# Altium schematic sheet ALARM SENSOR and CT SENSOR.SchDoc("ALARM SENSOR and CT SENSOR")
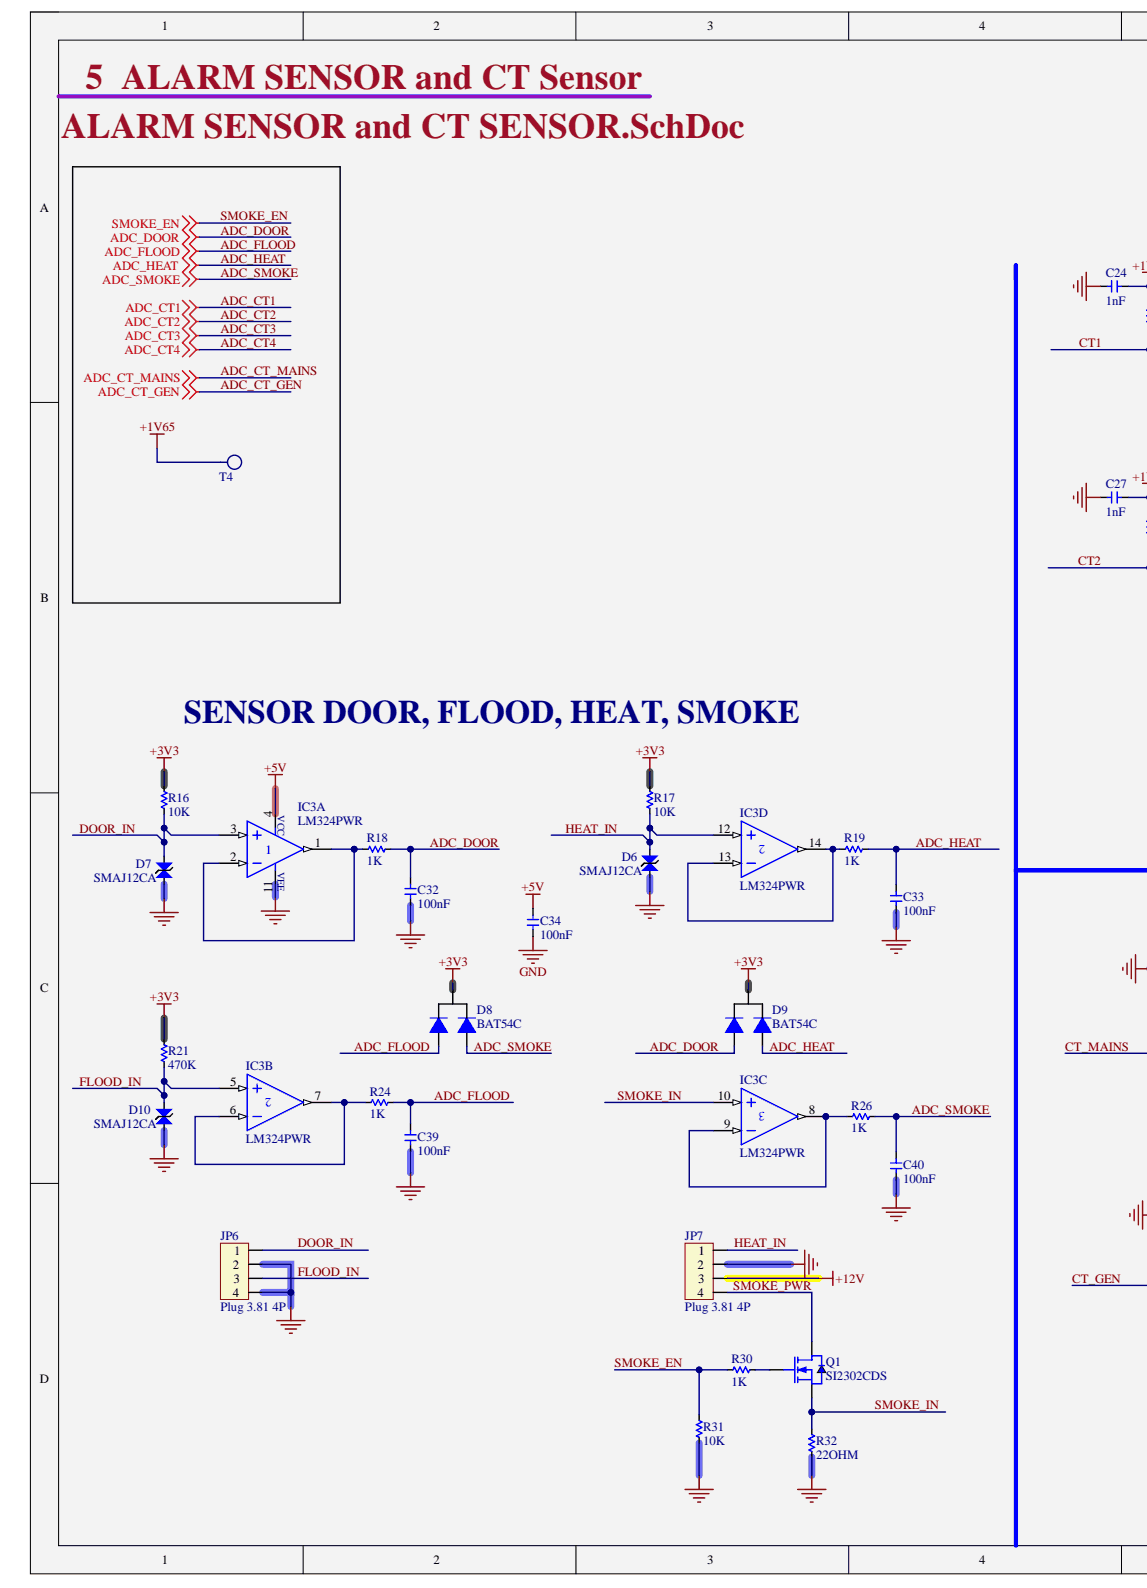
[diagram p0: ALARM SENSOR and CT SENSOR.SchDoc, left]
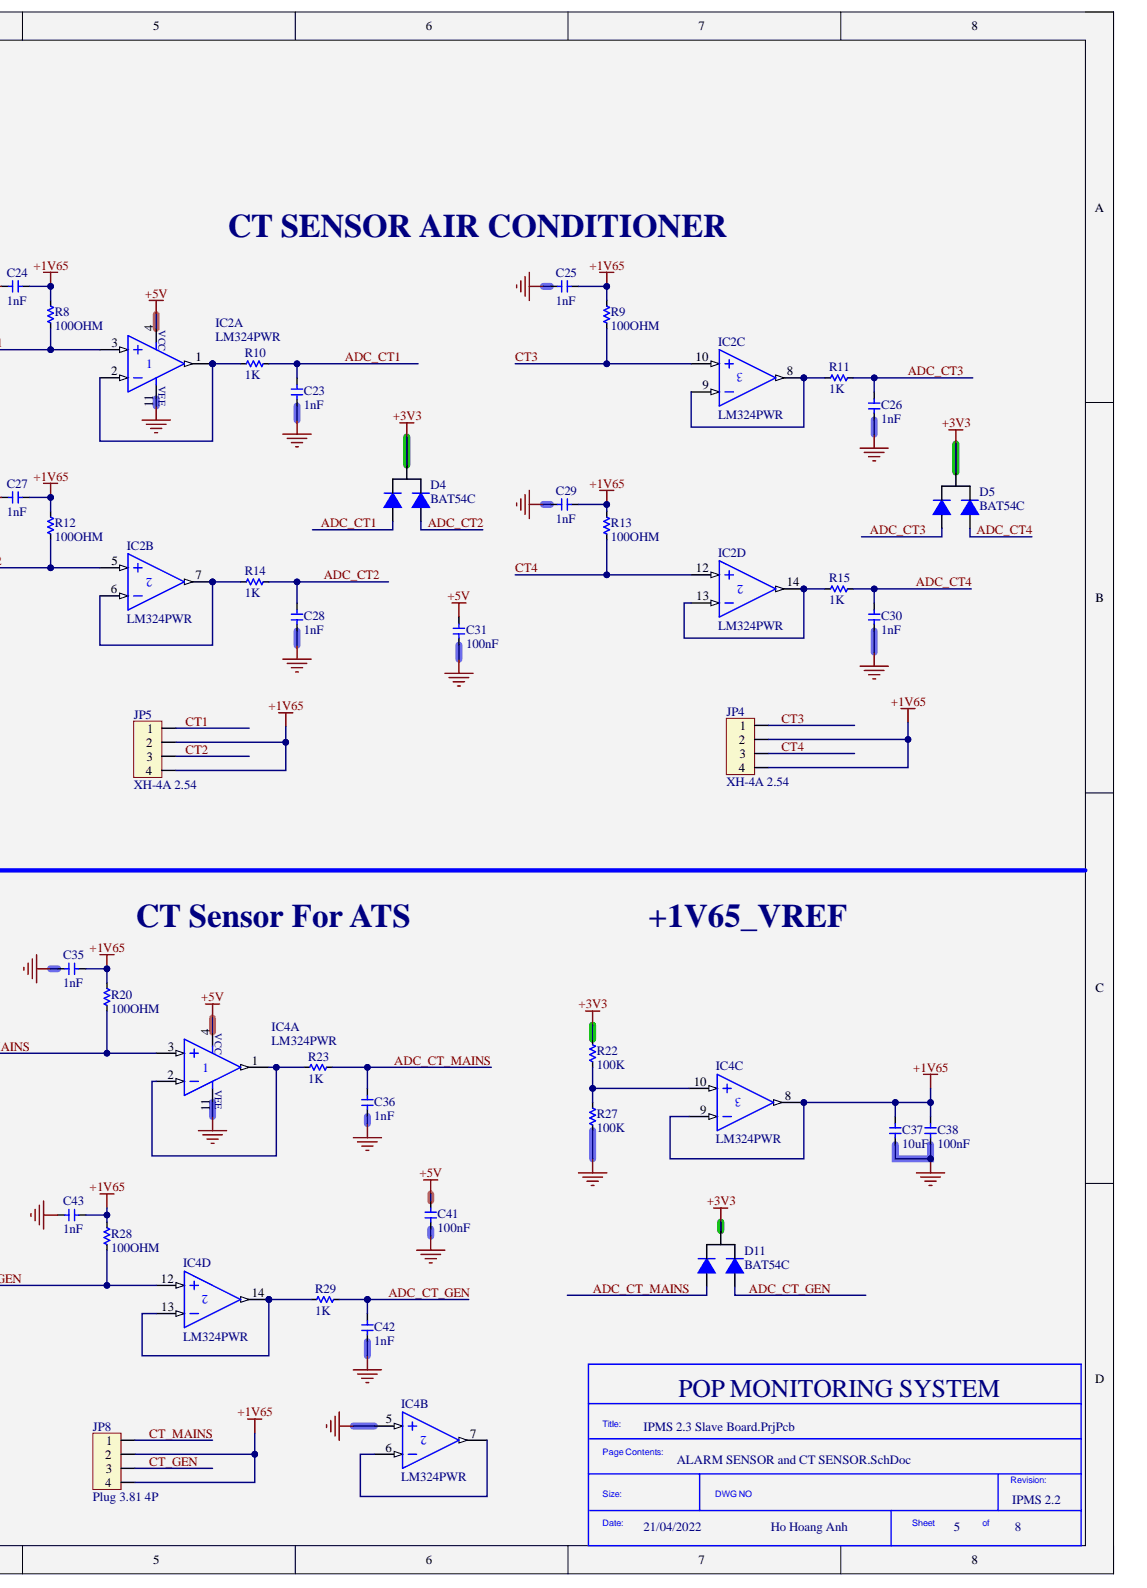
[diagram p1: ALARM SENSOR and CT SENSOR.SchDoc, right]

## Parts
C23  1nF  [C_0603]
C24  1nF  [C_0603]
C25  1nF  [C_0603]
C26  1nF  [C_0603]
C27  1nF  [C_0603]
C28  1nF  [C_0603]
C29  1nF  [C_0603]
C30  1nF  [C_0603]
C31  100nF  [C_0603]
C32  100nF  [C_0603]
C33  100nF  [C_0603]
C34  100nF  [C_0603]
C35  1nF  [C_0603]
C36  1nF  [C_0603]
C37  10uF  [C_0805]
C38  100nF  [C_0603]
C39  100nF  [C_0603]
C40  100nF  [C_0603]
C41  100nF  [C_0603]
C42  1nF  [C_0603]
C43  1nF  [C_0603]
D4  BAT54C  [SOT-23-3]
D5  BAT54C  [SOT-23-3]
D6  SMAJ12CA  [SMA_L4.4-W2.6-LS5.0-BI]
D7  SMAJ12CA  [SMA_L4.4-W2.6-LS5.0-BI]
D8  BAT54C  [SOT-23-3]
D9  BAT54C  [SOT-23-3]
D10  SMAJ12CA  [SMA_L4.4-W2.6-LS5.0-BI]
D11  BAT54C  [SOT-23-3]
IC2  LM324PWR  [TSSOP-14_L5.0-W4.4-P0.65-LS6.4-BL]  (parts A,B,C,D)
IC3  LM324PWR  [TSSOP-14_L5.0-W4.4-P0.65-LS6.4-BL]  (parts A,B,C,D)
IC4  LM324PWR  [TSSOP-14_L5.0-W4.4-P0.65-LS6.4-BL]  (parts A,B,C,D)
JP4  XH-4A 2.54  [XH-4A-2.54]
JP5  XH-4A 2.54  [XH-4A-2.54]
JP6  Plug 3.81 4P  [CONN-TH_1803442]
JP7  Plug 3.81 4P  [CONN-TH_1803442]
JP8  Plug 3.81 4P  [CONN-TH_1803442]
Q1  SI2302CDS  [SOT-23-3]
R8  100OHM  [R_0805]
R9  100OHM  [R_0805]
R10  1K  [R_0603]
R11  1K  [R_0603]
R12  100OHM  [R_0805]
R13  100OHM  [R_0805]
R14  1K  [R_0603]
R15  1K  [R_0603]
R16  10K  [R_0805]
R17  10K  [R_0805]
R18  1K  [R_0603]
R19  1K  [R_0603]
R20  100OHM  [R_0805]
R21  470K  [R_0805]
R22  100K  [R_0603]
R23  1K  [R_0603]
R24  1K  [R_0603]
R26  1K  [R_0603]
R27  100K  [R_0603]
R28  100OHM  [R_0805]
R29  1K  [R_0603]
R30  1K  [R_0603]
R31  10K  [R_0603]
R32  22OHM  [R_1206]
T4  TEST-POINT'4545'  [TP-D45MIL]  (value not drawn)  (no BOM)

## Nets
+1V65: C24.2 C25.2 C27.2 C29.1 C35.1 C37.2 C38.2 C43.2 IC4.8 IC4.9 JP4.2 JP4.4 JP5.2 JP5.4 JP8.2 JP8.4 R8.1 R9.1 R12.1 R13.1 R20.1 R28.1 T4.T
+3V3: D4.3 D5.3 D8.3 D9.3 D11.3 R16.1 R17.1 R21.1 R22.1
+5V: C31.2 C34.2 C41.2 IC2.4 IC3.4 IC4.4
+12V: JP7.3
GND: C23.1 C24.1 C25.1 C26.1 C27.1 C28.1 C29.2 C30.1 C31.1 C32.1 C33.1 C34.1 C35.2 C36.1 C37.1 C38.1 C39.1 C40.1 C41.1 C42.1 C43.1 D6.1 D7.1 D10.1 IC2.11 IC3.11 IC4.5 IC4.11 JP6.2 JP6.4 JP7.2 R27.2 R31.2 R32.2
ADC_CT1: C23.2 D4.2 R10.1
ADC_CT2: C28.2 D4.1 R14.1
ADC_CT3: C26.2 D5.2 R11.1
ADC_CT4: C30.2 D5.1 R15.1
ADC_CT_GEN: C42.2 D11.1 R29.1
ADC_CT_MAINS: C36.2 D11.2 R23.1
ADC_DOOR: C32.2 D9.2 R18.1
ADC_FLOOD: C39.2 D8.2 R24.1
ADC_HEAT: C33.2 D9.1 R19.1
ADC_SMOKE: C40.2 D8.1 R26.1
CT1: IC2.3 JP5.1 R8.2
CT2: IC2.5 JP5.3 R12.2
CT3: IC2.10 JP4.1 R9.2
CT4: IC2.12 JP4.3 R13.2
CT_GEN: IC4.12 JP8.3 R28.2
CT_MAINS: IC4.3 JP8.1 R20.2
DOOR_IN: D7.2 IC3.3 JP6.1 R16.2
FLOOD_IN: D10.2 IC3.5 JP6.3 R21.2
HEAT_IN: D6.2 IC3.12 JP7.1 R17.2
SMOKE_EN: R30.2 R31.1
SMOKE_IN: IC3.10 Q1.2 R32.1
SMOKE_PWR: JP7.4 Q1.3
NetIC2_1: IC2.1 IC2.2 R10.2
NetIC2_6: IC2.6 IC2.7 R14.2
NetIC2_8: IC2.8 IC2.9 R11.2
NetIC2_13: IC2.13 IC2.14 R15.2
NetIC3_1: IC3.1 IC3.2 R18.2
NetIC3_6: IC3.6 IC3.7 R24.2
NetIC3_8: IC3.8 IC3.9 R26.2
NetIC3_13: IC3.13 IC3.14 R19.2
NetIC4_1: IC4.1 IC4.2 R23.2
NetIC4_6: IC4.6 IC4.7
NetIC4_10: IC4.10 R22.2 R27.1
NetIC4_13: IC4.13 IC4.14 R29.2
NetQ1_1: Q1.1 R30.1

## Library data of the parts
C23-C30 C35 C36 C42 C43 = CL10B102KB8NNNC: 1NF 50V 0603 ROHS; Manufacturer: Samsung Electro-Mechanics; Assembly Type: SMT Assembly; Component Kind: Standard; LCSC Part Number: C1588; Manufacturer Part Number: CL10B102KB8NNNC; Package: 0603; Library Name: IPMS 2.3 Slave Board.SCHLIB
C31-C34 C38-C41 = CC0603KRX7R9BB104: 100nF 50V X7R ±10% 0603 Multilayer Ceramic Capacitors MLCC - SMD/SMT ROHS; Manufacturer: YAGEO; Assembly Type: SMT Assembly; Component Kind: Standard; LCSC Part Number: C14663; Manufacturer Part Number: CC0603KRX7R9BB104; Package: 0603; Library Name: IPMS 2.3 Slave Board.SCHLIB
C37 = CL21A106KAYNNNE: 10UF 25V 0805 ROHS; Manufacturer: Samsung Electro-Mechanics; Assembly Type: SMT Assembly; Component Kind: Standard; LCSC Part Number: C15850; Manufacturer Part Number: CL21A106KAYNNNE; Package: 0805; Library Name: IPMS 2.3 Slave Board.SCHLIB
D4 D5 D8 D9 D11 = BAT54C-7-F: DIODE ARRAY SCHOTTKY 30V SOT23-3; Manufacturer: Diodes; Assembly Type: SMT Assembly; Component Kind: Standard; LCSC Part Number: C134405; Manufacturer Part Number: BAT54C-7-F; Package: SOT-23-3; Library Name: IPMS 2.3 Slave Board.SCHLIB
D6 D7 D10 = SMAJ12CA: TVS DIODE 12V 19.9V SMA; Manufacturer: MDD（Microdiode Electronics）; Assembly Type: SMT Assembly; Component Kind: Standard; LCSC Part Number: C110042; Manufacturer Part Number: SMAJ12CA; Package: SMA,DO-214AC; Library Name: IPMS 2.3 Slave Board.SCHLIB
IC2-IC4 = LM324PWR: LM324AM/NOPB GENERAL PURPOSE AMPLIFIERS SOIC-14 ROHS; Manufacturer: Texas Instruments; Assembly Type: SMT Assembly; Component Kind: Standard; LCSC Part Number: C7942; Manufacturer Part Number: LM324PWR; Package: TSSOP-14_4.4x5.0x0.65P; Library Name: IPMS 2.3 Slave Board.SCHLIB
JP4 JP5 = XH-4A 2.54: 1x4P, Brass Plugin,P=2.54mm Wire To Board / Wire To Wire Connector ROHS; Manufacturer: China; Assembly Type: Hand Soldering; Component Kind: Standard; LCSC Part Number: N/A; Manufacturer Part Number: XH-4A 2.54; Package: CONN-TH_4P_XH Pitch=2.54; Library Name: IPMS 2.3 Slave Board.SCHLIB
JP6-JP8 = 1803442: 160V 8A 4 Straight pin 1 3.81mm Green Board Edge/Receptacle P=3.81mm Pluggable System Terminal Block ROHS; Manufacturer: Phoenix Contact; Assembly Type: Hand Soldering; Component Kind: Standard; LCSC Part Number: C480518; Manufacturer Part Number: 1803442; Package: CONN-TH_1803442; Library Name: IPMS 2.3 Slave Board.SCHLIB
Q1 = SI2302CDS-T1-GE3: MOSFET N-CH 20V 2.6A SOT-23; Manufacturer: Vishay Siliconix; Assembly Type: SMT Assembly; Component Kind: Standard; LCSC Part Number: C10488; Manufacturer Part Number: Si2302CDS-T1-GE3; Package: SOT-23-3; Library Name: IPMS 2.3 Slave Board.SCHLIB
R8 R9 R12 R13 R20 R28 = 0805W8F1000T5E: 100OHMS ±1% 1/8W 0805 ROHS; Manufacturer: Uniroyal Elec; Assembly Type: SMT Assembly; Component Kind: Standard; LCSC Part Number: C17408; Manufacturer Part Number: 0805W8F1000T5E; Package: 0805; Library Name: IPMS 2.3 Slave Board.SCHLIB
R10 R11 R14 R15 R18 R19 R23 R24 R26 R29 R30 = 0603WAF1001T5E: 1KOHMS ±1% 1/10W 0603 ROHS; Manufacturer: Uniroyal Elec; Assembly Type: SMT Assembly; Component Kind: Standard; LCSC Part Number: C21190; Manufacturer Part Number: 0603WAF1001T5E; Package: 0603; Library Name: IPMS 2.3 Slave Board.SCHLIB
R16 R17 = 0805W8F1002T5E: 10KOHMS ±1% 1/8W 0805 ROHS; Manufacturer: Uniroyal Elec; Assembly Type: SMT Assembly; Component Kind: Standard; LCSC Part Number: C17414; Manufacturer Part Number: 0805W8F1002T5E; Package: 0805; Library Name: IPMS 2.3 Slave Board.SCHLIB
R21 = 0805W8F4703T5E: 470kΩ 0805 Chip Resistor - Surface Mount ROHS ±1% 125mW Thick Film Resistors 150V ±100ppm/℃ -55℃~+155℃; Manufacturer: Uniroyal Elec; Assembly Type: SMT Assembly; Component Kind: Standard; LCSC Part Number: C17709; Manufacturer Part Number: 0805W8F4703T5E; Package: 0805; Library Name: IPMS 2.3 Slave Board.SCHLIB
R22 R27 = 0603WAF1003T5E: 100KOHMS ±1% 1/10W 0603 ROHS; Manufacturer: Uniroyal Elec; Assembly Type: SMT Assembly; Component Kind: Standard; LCSC Part Number: C25803; Manufacturer Part Number: 0603WAF1003T5E; Package: 0603; Library Name: IPMS 2.3 Slave Board.SCHLIB
R31 = 0603WAF1002T5E: 10KOHMS ±1% 1/10W 0603 ROHS; Manufacturer: Uniroyal Elec; Assembly Type: SMT Assembly; Component Kind: Standard; LCSC Part Number: C25804; Manufacturer Part Number: 0603WAF1002T5E; Package: 0603; Library Name: IPMS 2.3 Slave Board.SCHLIB
R32 = 1206W4F220JT5E: 22Ω 1206 ±1% 1/4W Thick Film Resistors 200V ±100ppm/℃ -55℃~+155℃; Manufacturer: Uniroyal Elec; Assembly Type: SMT Assembly; Component Kind: Standard; LCSC Part Number: C17958; Manufacturer Part Number: 1206W4F220JT5E; Package: 1206; Library Name: IPMS 2.3 Slave Board.SCHLIB
T4 = TEST-POINT'4545': Manufacturer: No; Description: TEST-POINT'4545'; LCSC Part Number: No; Manufacturer Part Number: No; Package: No; Library Name: IPMS 2.3 Slave Board.SchLib
